# Altium schematic sheet one_side_term_J6.SchDoc
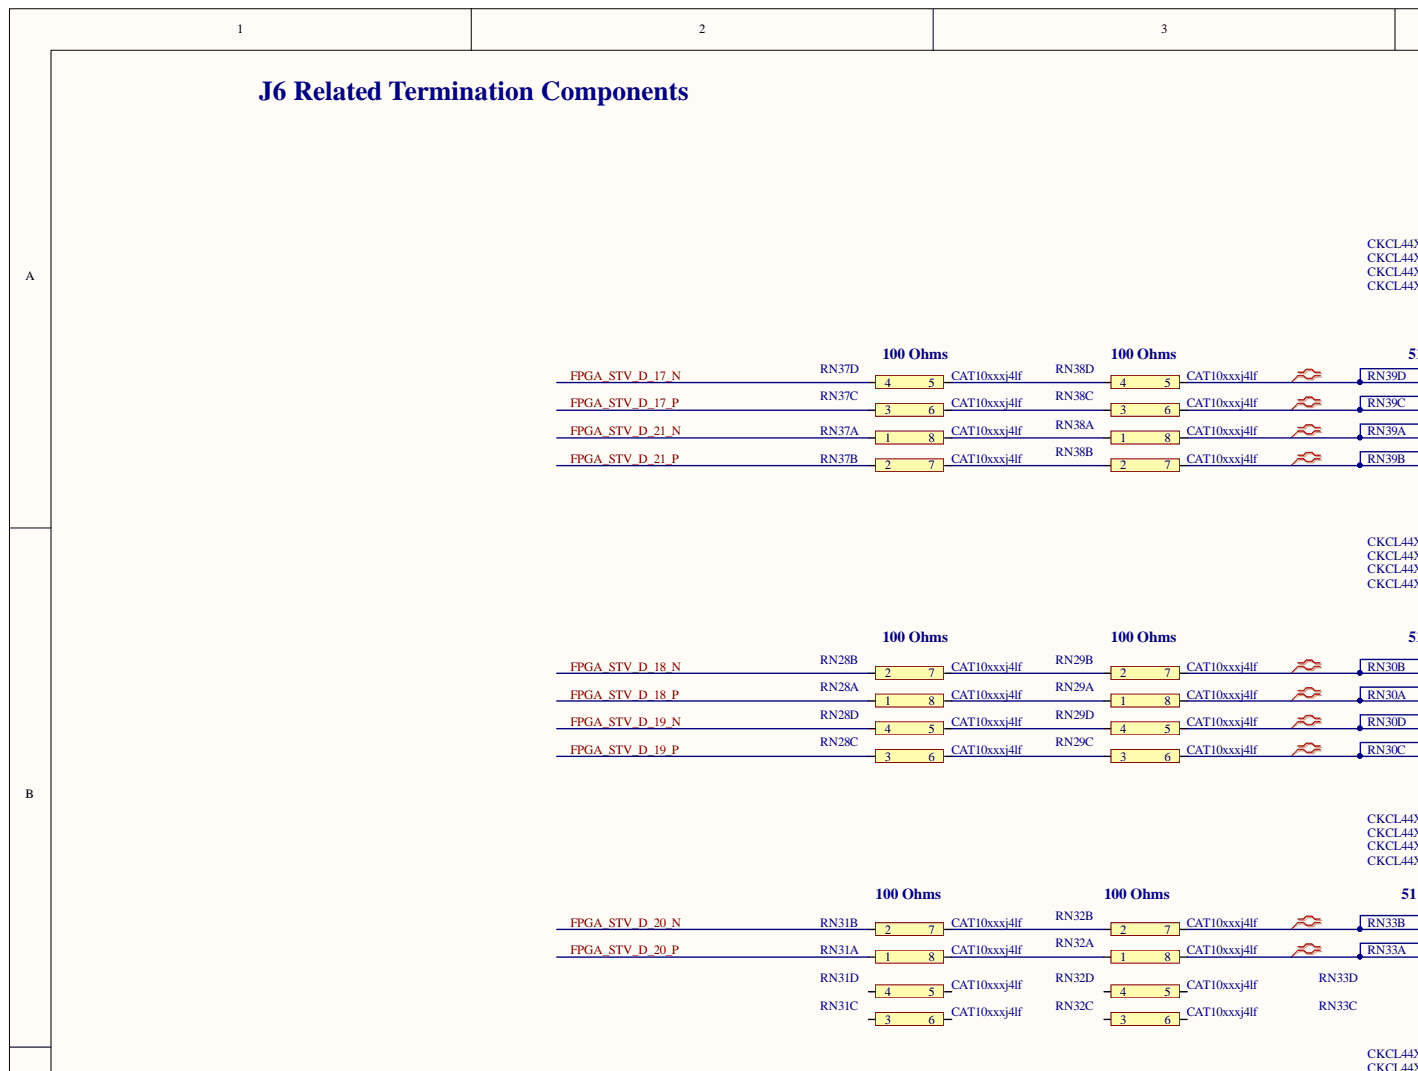
[diagram p0: one_side_term_J6.SchDoc, top left]
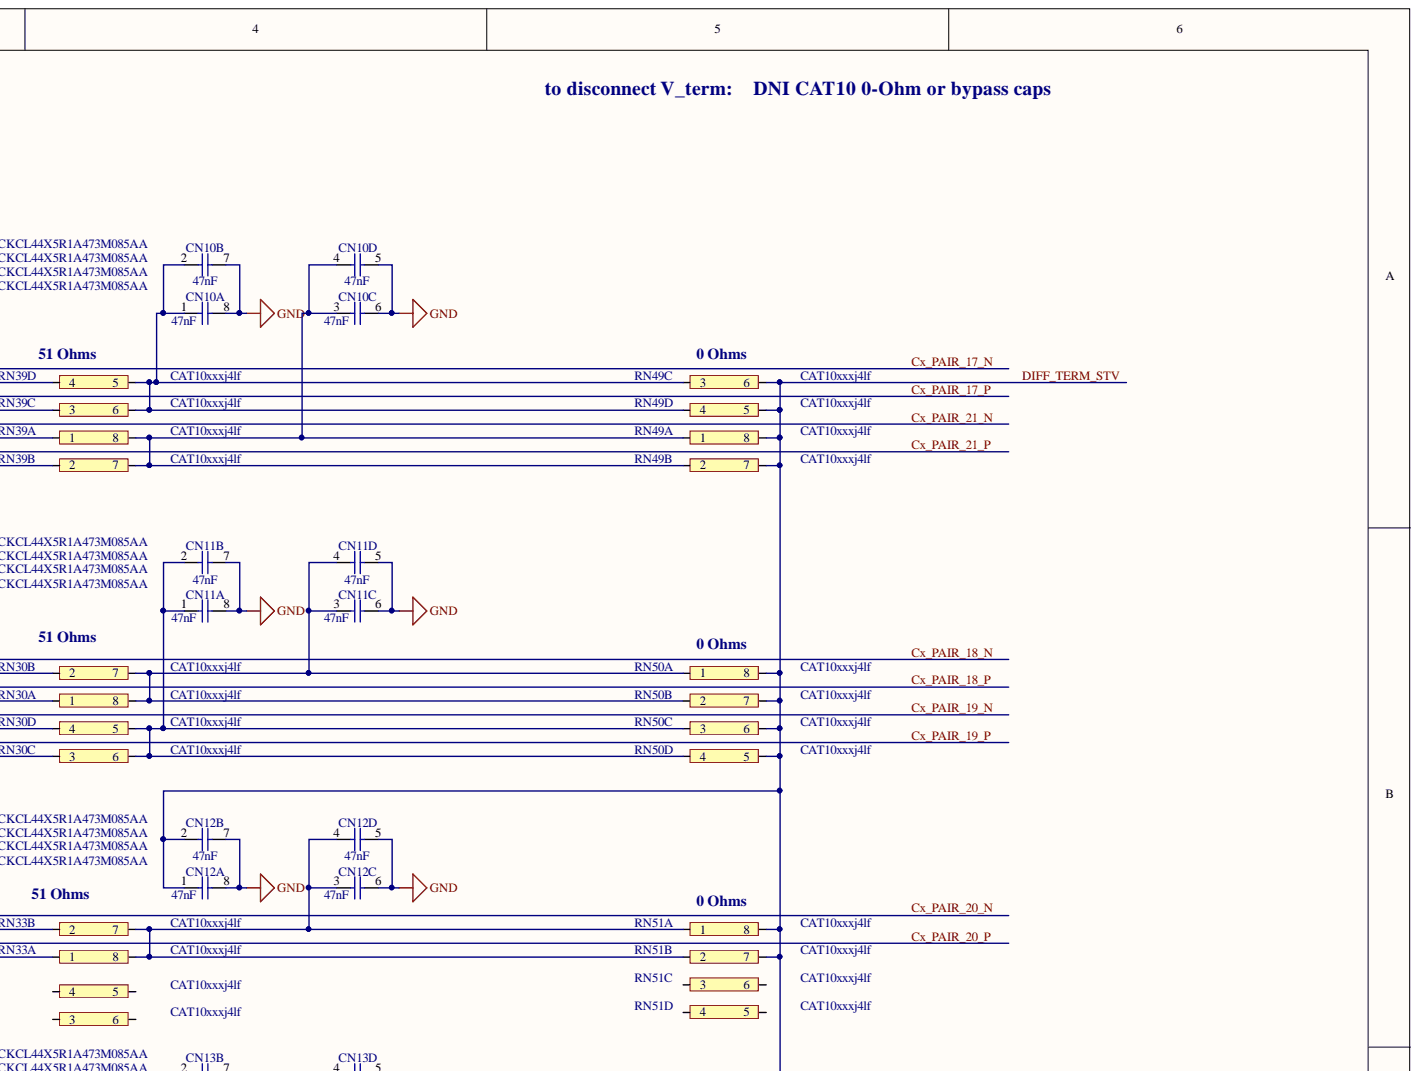
[diagram p1: one_side_term_J6.SchDoc, top right]
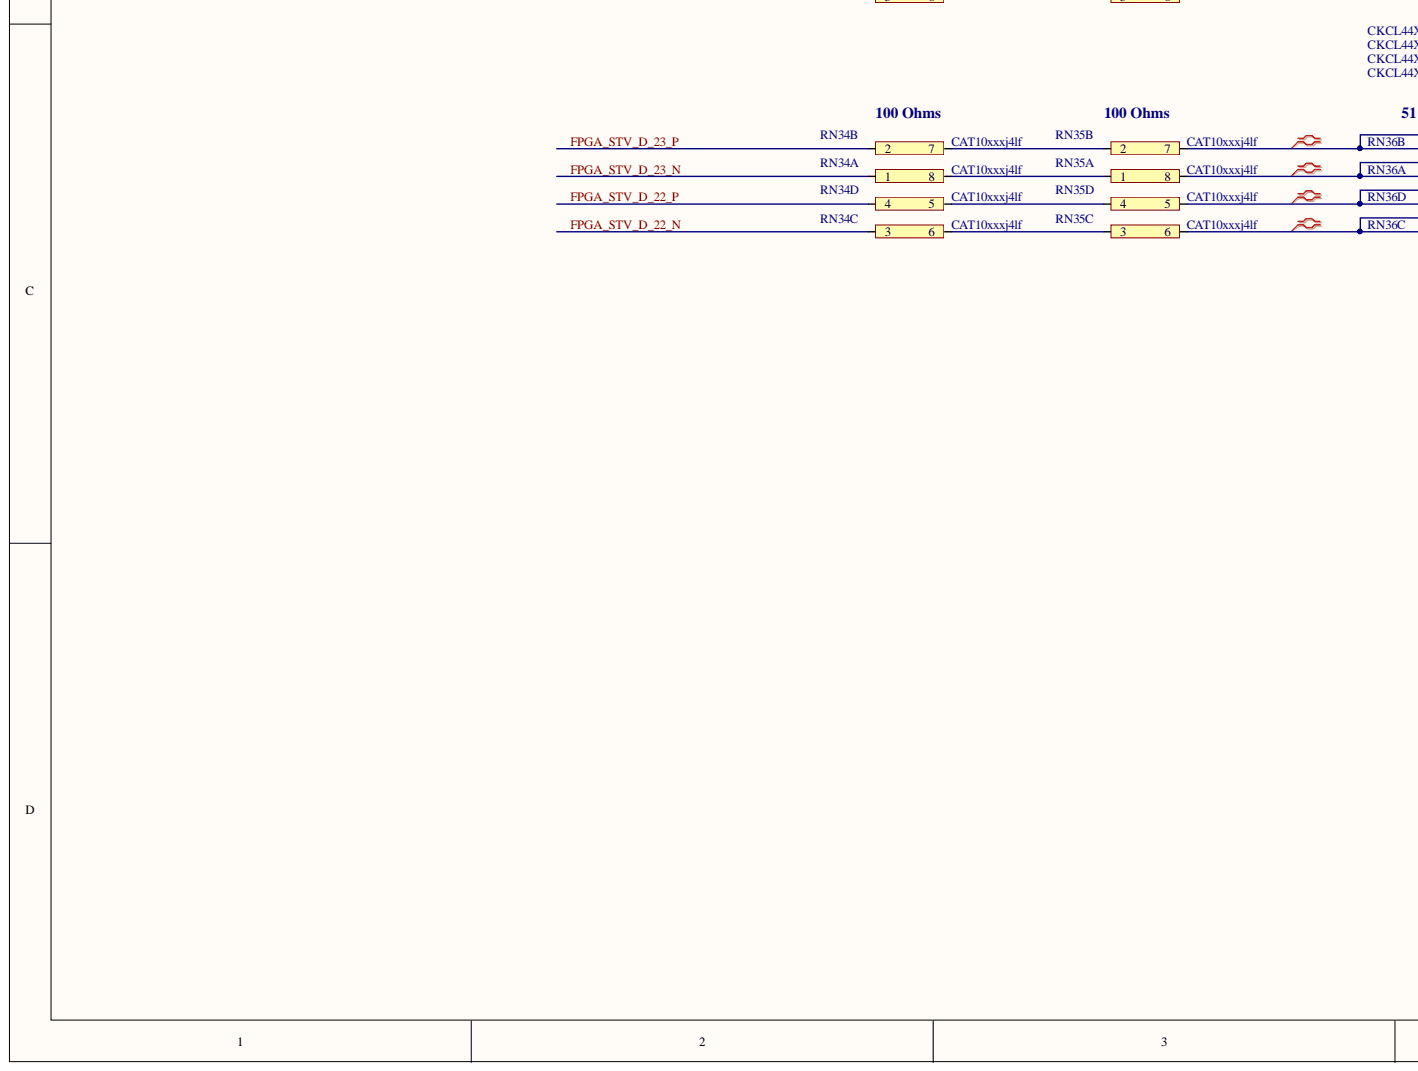
[diagram p2: one_side_term_J6.SchDoc, bottom left]
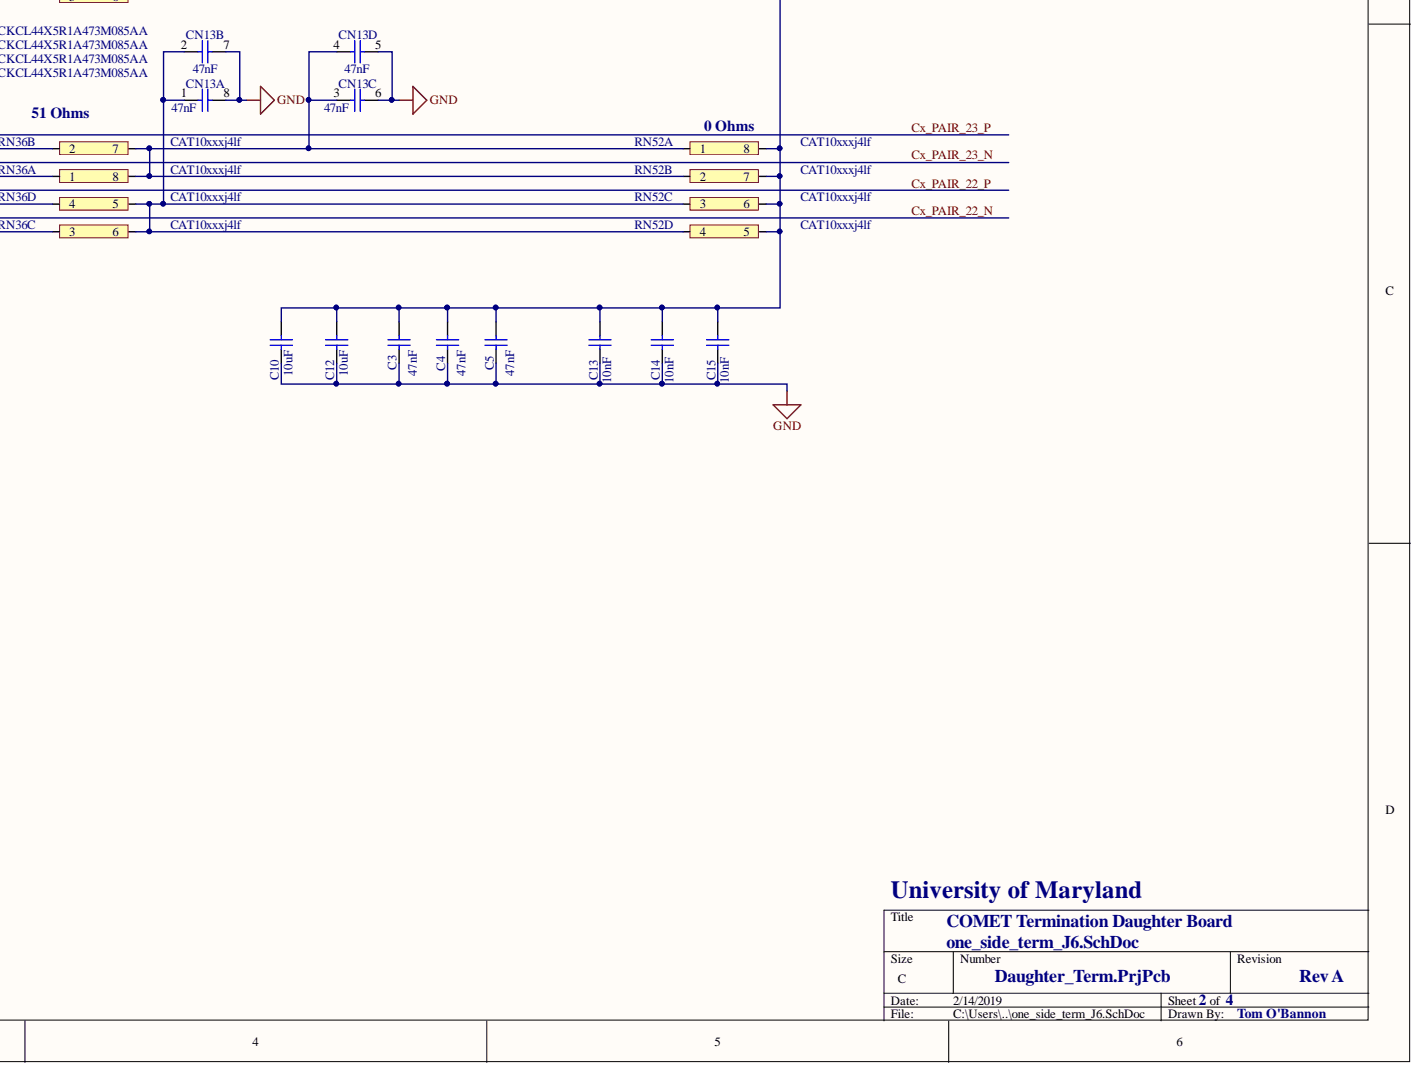
[diagram p3: one_side_term_J6.SchDoc, bottom right]

## Parts
C3  47nF  [CAPC2012X135N]
C4  47nF  [CAPC2012X135N]
C5  47nF  [CAPC2012X135N]
C10  10uF  [CAPC2012X135N]
C12  10uF  [CAPC2012X135N]
C13  10nF  [CAPC2012X135N]
C14  10nF  [CAPC2012X135N]
C15  10nF  [CAPC2012X135N]
CN10  47nF  Manufacturer Part Number: CKCL44X5R1A473M085AA  [CAPCAF50P125X200X100-8N]  (parts A,B,C,D)
CN11  47nF  Manufacturer Part Number: CKCL44X5R1A473M085AA  [CAPCAF50P125X200X100-8N]  (parts A,B,C,D)
CN12  47nF  Manufacturer Part Number: CKCL44X5R1A473M085AA  [CAPCAF50P125X200X100-8N]  (parts A,B,C,D)
CN13  47nF  Manufacturer Part Number: CKCL44X5R1A473M085AA  [CAPCAF50P125X200X100-8N]  (parts A,B,C,D)
RN28  CAT10xxxj4lf  [CAT10xxxj4lf]  (parts A,B,C,D)
RN29  CAT10xxxj4lf  [CAT10xxxj4lf]  (parts A,B,C,D)
RN30  CAT10xxxj4lf  [CAT10xxxj4lf]  (parts A,B,C,D)
RN31  CAT10xxxj4lf  [CAT10xxxj4lf]  (parts A,B,C,D)
RN32  CAT10xxxj4lf  [CAT10xxxj4lf]  (parts A,B,C,D)
RN33  CAT10xxxj4lf  [CAT10xxxj4lf]  (parts A,B,C,D)
RN34  CAT10xxxj4lf  [CAT10xxxj4lf]  (parts A,B,C,D)
RN35  CAT10xxxj4lf  [CAT10xxxj4lf]  (parts A,B,C,D)
RN36  CAT10xxxj4lf  [CAT10xxxj4lf]  (parts A,B,C,D)
RN37  CAT10xxxj4lf  [CAT10xxxj4lf]  (parts A,B,C,D)
RN38  CAT10xxxj4lf  [CAT10xxxj4lf]  (parts A,B,C,D)
RN39  CAT10xxxj4lf  [CAT10xxxj4lf]  (parts A,B,C,D)
RN49  CAT10xxxj4lf  [CAT10xxxj4lf]  (parts A,B,C,D)
RN50  CAT10xxxj4lf  [CAT10xxxj4lf]  (parts A,B,C,D)
RN51  CAT10xxxj4lf  [CAT10xxxj4lf]  (parts A,B,C,D)
RN52  CAT10xxxj4lf  [CAT10xxxj4lf]  (parts A,B,C,D)

## Nets
GND: C3.1 C4.1 C5.1 C10.1 C12.1 C13.1 C14.1 C15.1 CN10.5 CN10.6 CN10.7 CN10.8 CN11.5 CN11.6 CN11.7 CN11.8 CN12.5 CN12.6 CN12.7 CN12.8 CN13.5 CN13.6 CN13.7 CN13.8
CX_PAIR_17_N: RN38.5 RN39.4
CX_PAIR_17_P: RN38.6 RN39.3
CX_PAIR_18_N: RN29.7 RN30.2
CX_PAIR_18_P: RN29.8 RN30.1
CX_PAIR_19_N: RN29.5 RN30.4
CX_PAIR_19_P: RN29.6 RN30.3
CX_PAIR_20_N: RN32.7 RN33.2
CX_PAIR_20_P: RN32.8 RN33.1
CX_PAIR_21_N: RN38.8 RN39.1
CX_PAIR_21_P: RN38.7 RN39.2
CX_PAIR_22_N: RN35.6 RN36.3
CX_PAIR_22_P: RN35.5 RN36.4
CX_PAIR_23_N: RN35.8 RN36.1
CX_PAIR_23_P: RN35.7 RN36.2
DIFF_TERM_STV: C3.2 C4.2 C5.2 C10.2 C12.2 C13.2 C14.2 C15.2 CN12.1 CN12.2 RN49.5 RN49.6 RN49.7 RN49.8 RN50.5 RN50.6 RN50.7 RN50.8 RN51.7 RN51.8 RN52.5 RN52.6 RN52.7 RN52.8
FPGA_STV_D_17_N: RN37.4
FPGA_STV_D_17_P: RN37.3
FPGA_STV_D_18_N: RN28.2
FPGA_STV_D_18_P: RN28.1
FPGA_STV_D_19_N: RN28.4
FPGA_STV_D_19_P: RN28.3
FPGA_STV_D_20_N: RN31.2
FPGA_STV_D_20_P: RN31.1
FPGA_STV_D_21_N: RN37.1
FPGA_STV_D_21_P: RN37.2
FPGA_STV_D_22_N: RN34.3
FPGA_STV_D_22_P: RN34.4
FPGA_STV_D_23_N: RN34.1
FPGA_STV_D_23_P: RN34.2
NetCN10_1: CN10.1 CN10.2 RN39.5 RN39.6 RN49.3 RN49.4
NetCN10_3: CN10.3 CN10.4 RN39.7 RN39.8 RN49.1 RN49.2
NetCN11_1: CN11.1 CN11.2 RN30.5 RN30.6 RN50.3 RN50.4
NetCN11_3: CN11.3 CN11.4 RN30.7 RN30.8 RN50.1 RN50.2
NetCN12_3: CN12.3 CN12.4 RN33.7 RN33.8 RN51.1 RN51.2
NetCN13_1: CN13.1 CN13.2 RN36.5 RN36.6 RN52.3 RN52.4
NetCN13_3: CN13.3 CN13.4 RN36.7 RN36.8 RN52.1 RN52.2
NetRN28_5: RN28.5 RN29.4
NetRN28_6: RN28.6 RN29.3
NetRN28_7: RN28.7 RN29.2
NetRN28_8: RN28.8 RN29.1
NetRN31_7: RN31.7 RN32.2
NetRN31_8: RN31.8 RN32.1
NetRN34_5: RN34.5 RN35.4
NetRN34_6: RN34.6 RN35.3
NetRN34_7: RN34.7 RN35.2
NetRN34_8: RN34.8 RN35.1
NetRN37_5: RN37.5 RN38.4
NetRN37_6: RN37.6 RN38.3
NetRN37_7: RN37.7 RN38.2
NetRN37_8: RN37.8 RN38.1

Not connected: RN31 3 4 5 6; RN32 3 4 5 6; RN33 3 4 5 6; RN51 3 4 5 6

## Library data of the parts
C3-C5 = CC0805_100NF_50V_10%_X7R: Non-polarised capacitor; Manufacturer: GENERIC; Manufacturer1 Part Number: B37941K5104K62; Part Description: SMD Multilayer Chip Ceramic Capacitor; Database Table Name: Capacitors SMD; TC: X7R; Tolerance: ±10%; Voltage: 10V; Case: 0805; Component Kind: Standard; Component Type: Standard; CreateDate: 12/3/2007; HelpURL: \\cern.ch\dfs\Applications\Altium\Datasheets\CC0805_X7R_EPCOS.pdf; LatestRevisionDate: 12/3/2007; Library Name: Capacitors.DbLib; Manufacturer1 ComponentHeight: 1.35mm; Manufacturer1 Example: EPCOS; Manufacturer Part Number: CC0805_100NF_50V_10%_X7R; Mounted: Yes; Part Number: CC0805_100NF_50V_10%_X7R; Pin Count: 2; SMD: Yes; Socket: No; Status: Preferred; Table: Capacitors SMD; Value: 47nF; Author: CERN DEM JLC
C10 C12 = CC0805_10UF_10V_10%_X7R: Non-polarised capacitor; Manufacturer: GENERIC; Manufacturer1 Part Number: GRM21BR71A106KE51L; Part Description: SMD Multilayer Chip Ceramic Capacitor; Database Table Name: Capacitors SMD; TC: X7R; Tolerance: ±10%; Voltage: 10V; Case: 0805; Component Kind: Standard; Component Type: Standard; CreateDate: 4/30/2012; HelpURL: \\cern.ch\dfs\Applications\Altium\Datasheets\CC0805_X7R_MURATA.pdf; LatestRevisionDate: 4/30/2012; Library Name: Capacitors.DbLib; Manufacturer1 ComponentHeight: 1.35mm; Manufacturer1 Example: MURATA; Manufacturer Part Number: CC0805_10UF_10V_10%_X7R; Mounted: Yes; Part Number: CC0805_10UF_10V_10%_X7R; Pin Count: 2; SMD: Yes; Socket: No; Status: Preferred; Table: Capacitors SMD; Value: 10uF; Author: CERN DEM JLC
C13-C15 = CC0805_10NF_50V_5%_X7R: Non-polarised capacitor; Manufacturer: GENERIC; Manufacturer1 Part Number: 08055C103JAT2A; Part Description: SMD Multilayer Chip Ceramic Capacitor; Database Table Name: Capacitors SMD; TC: X7R; Tolerance: ±5%; Voltage: 50V; Case: 0805; Component Kind: Standard; Component Type: Standard; CreateDate: 1/18/2011; HelpURL: \\cern.ch\dfs\Applications\Altium\Datasheets\CC0805_X7R_AVX.pdf; LatestRevisionDate: 1/18/2011; Library Name: Capacitors.DbLib; Manufacturer1 ComponentHeight: 0.94mm; Manufacturer1 Example: AVX; Manufacturer Part Number: CC0805_10NF_50V_5%_X7R; Mounted: Yes; Part Number: CC0805_10NF_50V_5%_X7R; Pin Count: 2; SMD: Yes; Socket: No; Status: None; Table: Capacitors SMD; Value: 10nF; Author: CERN DEM JLC
CN10-CN13 = CN_100NF_6.3V_20%_X5R_TDK_CKCL44: DIL8 Capacitor Network 4 Isolated Capacitors; Manufacturer: TDK; Part Description: Multilayer Ceramic Chip Capacitors Array (4 Isolated Capacitors); Database Table Name: Capacitors Networks SMD; TC: X5R; Tolerance: ±20%; Voltage: 10V; Case: 2012; ComponentHeight: 1mm; Component Kind: Standard; Component Type: Standard; CreateDate: 12/9/2010; HelpURL: \\cern.ch\dfs\Applications\Altium\Datasheets\CN_TDK_CKCL44.pdf; LatestRevisionDate: 12/9/2010; Library Name: Capacitors.DbLib; Mounted: Yes; PackageDescription: Capacitor,non-polarized,Chip Array,0.50mm pitch;8 pin,1.25mm W X 2.00mm L X 1.00mm H, IPC Medium Density; Part Number: CN_100NF_6.3V_20%_X5R_TDK_CKCL44; Pin Count: 8; SMD: Yes; Socket: No; Status: None; Table: Capacitors Networks SMD; Value: 47nF; Author: CERN DEM JLC
RN28-RN39 RN49-RN52 = CAT10xxxj4lf: Component Kind: Standard
  RN28-RN30 RN33-RN36 RN39 RN49-RN52: Library Name: Intg_Lib_ResNets.IntLib
  RN31 RN32 RN37 RN38: Library Name: Intrg_Lib_ResNets.IntLib
